# Altium schematic sheet USB_HUB.SchDoc(USB_HUB)
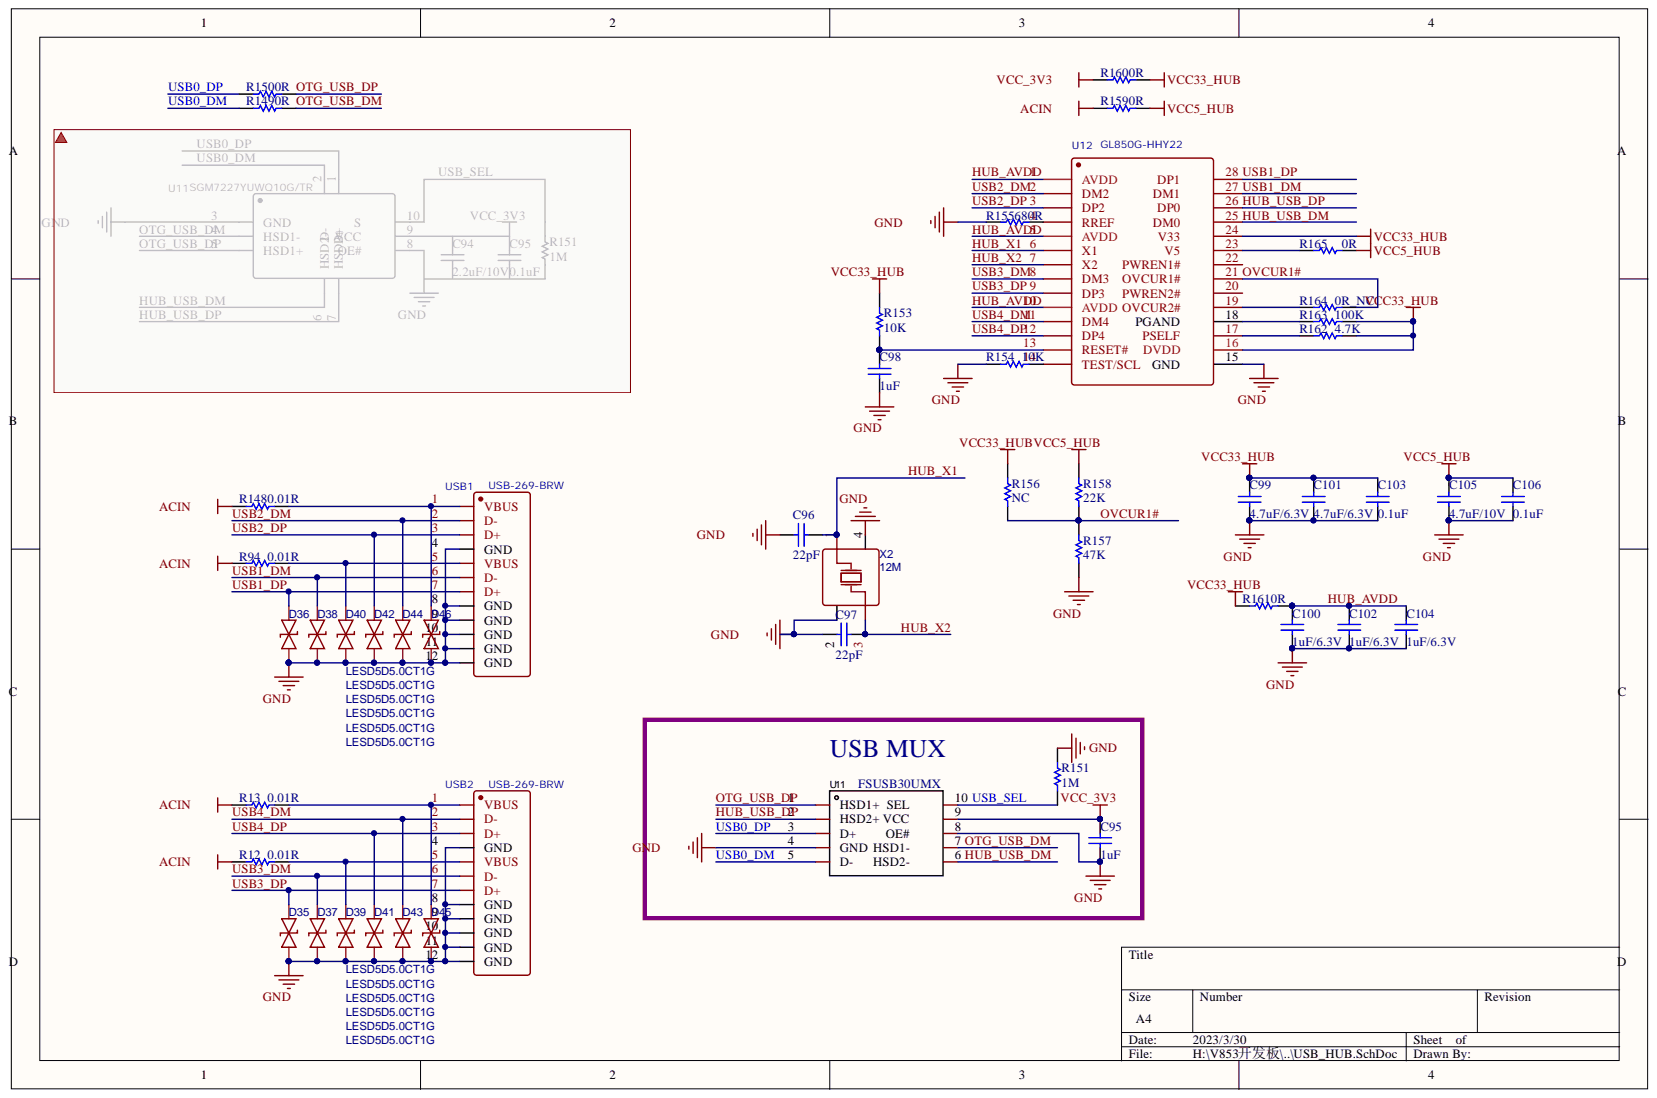
[diagram p0: USB_HUB.SchDoc]

## Parts
C95  1uF  [0402]
C96  22pF  [0402]
C97  22pF  [0402]
C98  1uF  [0402]
C99  4.7uF/6.3V  [0402]
C100  1uF/6.3V  [0402]
C101  4.7uF/6.3V  [0402]
C102  1uF/6.3V  [0402]
C103  0.1uF  [0402]
C104  1uF/6.3V  [0402]
C105  4.7uF/10V  [0402]
C106  0.1uF  [0402]
D35  LESD5D5.0CT1G  [SOD-523_L1.2-W0.8-LS1.6-BI]
D36  LESD5D5.0CT1G  [SOD-523_L1.2-W0.8-LS1.6-BI]
D37  LESD5D5.0CT1G  [SOD-523_L1.2-W0.8-LS1.6-BI]
D38  LESD5D5.0CT1G  [SOD-523_L1.2-W0.8-LS1.6-BI]
D39  LESD5D5.0CT1G  [SOD-523_L1.2-W0.8-LS1.6-BI]
D40  LESD5D5.0CT1G  [SOD-523_L1.2-W0.8-LS1.6-BI]
D41  LESD5D5.0CT1G  [SOD-523_L1.2-W0.8-LS1.6-BI]
D42  LESD5D5.0CT1G  [SOD-523_L1.2-W0.8-LS1.6-BI]
D43  LESD5D5.0CT1G  [SOD-523_L1.2-W0.8-LS1.6-BI]
D44  LESD5D5.0CT1G  [SOD-523_L1.2-W0.8-LS1.6-BI]
D45  LESD5D5.0CT1G  [SOD-523_L1.2-W0.8-LS1.6-BI]
D46  LESD5D5.0CT1G  [SOD-523_L1.2-W0.8-LS1.6-BI]
R12  0.01R  [0805R]
R13  0.01R  [0805R]
R94  0.01R  [0805R]
R148  0.01R  [0805R]
R149  0R  [0402]
R150  0R  [0402]
R151  1M  [0402]
R153  10K  [0402]
R154  10K  [0402]
R155  680R  [0402]
R156  NC  [0402]
R157  47K  [0402]
R158  22K  [0402]
R159  0R  [0402]
R160  0R  [0402]
R161  0R  [0402]
R162  4.7K  [0402]
R163  100K  [0402]
R164  0R_NC  [0402]
R165  0R  [0402]
U11  FSUSB30UMX  [UMLP-10_L1.8-W1.4-P0.40-BL]
U12  GL850G-HHY22  [SSOP-28_L10.2-W5.3-P0.65-LS7.8-BL]
USB1  USB-269-BRW  [USB-A-TH_USB-269-BRW]
USB2  USB-269-BRW  [USB-A-TH_USB-269-BRW]
X2  12M  [OSC-SMD_4P-L3.2-W2.5-BL]

## Nets
ACIN: R12.2 R13.2 R94.2 R148.2 R159.2
GND: C95.1 C96.1 C97.1 C98.2 C99.1 C100.1 C101.1 C102.1 C103.2 C104.1 C105.1 C106.2 D35.1 D36.1 D37.1 D38.1 D39.1 D40.1 D41.1 D42.1 D43.1 D44.1 D45.1 D46.1 R151.1 R154.2 R155.2 R157.1 U11.4(GND) U11.8 U12.15 USB1.4(GND) USB1.8(GND) USB1.9(GND) USB1.10(GND) USB1.11(GND) USB1.12(GND) USB2.4(GND) USB2.8(GND) USB2.9(GND) USB2.10(GND) USB2.11(GND) USB2.12(GND) X2.2 X2.4
VCC5_HUB: C105.2 C106.1 R158.2 R159.1 R165.1
VCC33_HUB: C99.2 C101.2 C103.1 R153.1 R156.2 R160.1 R161.2 R162.1 R163.1 U12.16 U12.24
VCC_3V3: C95.2 R160.2 U11.9
HUB_AVDD: C100.2 C102.2 C104.2 R161.1 U12.1(AVDD) U12.5(AVDD) U12.10
HUB_USB_DM: U11.6 U12.25
HUB_USB_DP: U11.2 U12.26
HUB_X1: C96.2 U12.6(X1) X2.1
HUB_X2: C97.2 U12.7(X2) X2.3
OTG_USB_DM: R149.1 U11.7
OTG_USB_DP: R150.1 U11.1(HSD1+)
OVCUR1#: R156.1 R157.2 R158.1 R164.1 U12.21
USB0_DM: R149.2 U11.5(D-)
USB0_DP: R150.2 U11.3(D+)
USB1_DM: D38.2 U12.27 USB1.6(D-)
USB1_DP: D36.2 U12.28 USB1.7(D+)
USB2_DM: D44.2 U12.2(DM2) USB1.2(D-)
USB2_DP: D42.2 U12.3(DP2) USB1.3(D+)
USB3_DM: D37.2 U12.8(DM3) USB2.6(D-)
USB3_DP: D35.2 U12.9(DP3) USB2.7(D+)
USB4_DM: D43.2 U12.11(DM4) USB2.2(D-)
USB4_DP: D41.2 U12.12(DP4) USB2.3(D+)
USB_SEL: R151.2 U11.10
NetC98_1: C98.1 R153.2 U12.13(RESET#)
NetD39_2: D39.2 R12.1 USB2.5(VBUS)
NetD40_2: D40.2 R94.1 USB1.5(VBUS)
NetD45_2: D45.2 R13.1 USB2.1(VBUS)
NetD46_2: D46.2 R148.1 USB1.1(VBUS)
NetR154_1: R154.1 U12.14(TEST/SCL)
NetR155_1: R155.1 U12.4(RREF)
NetR162_2: R162.2 U12.17
NetR163_2: R163.2 U12.18
NetR164_2: R164.2 U12.19
NetR165_2: R165.2 U12.23

Not connected: U12 20 22

## Library data of the parts
C95-C106 = Cap: Capacitor; PartNumber: CAP100NF; Component Kind: Standard; LatestRevisionDate: 17-Jul-2002; LatestRevisionNote: Re-released for DXP Platform.; Library Name: Miscellaneous Devices.IntLib; PackageReference: 0402; Published: 8-Jun-2000; Signal Integrity: Cap; Simulation: CAP; Publisher: Altium Limited
  C95 C98: Value: 1uF
  C96 C97: Value: 22pF
  C99 C101: Value: 4.7uF/6.3V
  C100 C102 C104: Value: 1uF/6.3V
  C103 C106: Value: 0.1uF
  C105: Value: 4.7uF/10V
D35-D46 = LESD5D5.0CT1G: BOM_Manufacturer: Leshan Radio; Contributor: LCSC; BOM_JLCPCB Part Class: Extended Part; BOM_Manufacturer Part: LESD5D5.0CT1G; BOM_Supplier: LCSC; BOM_Supplier Part: C383211; Component Kind: Standard; spicePre: D; spiceSymbolName: LESD5D5.0CT1G
R12 R13 R94 R148 R151 R153-R158 R162-R165 = Res1: Resistor; PartNumber: RES22R; Component Kind: Standard; LatestRevisionDate: 17-Jul-2002; LatestRevisionNote: Re-released for DXP Platform.; Library Name: Miscellaneous Devices.IntLib; PackageReference: 0402; Published: 8-Jun-2000; Signal Integrity: Res; Simulation: RESISTOR; Publisher: Altium Limited
  R12 R13 R94 R148: Value: 0.01R
  R151: Value: 1M
  R153 R154: Value: 10K
  R155: Value: 680R
  R156: Value: NC
  R157: Value: 47K
  R158: Value: 22K
  R162: Value: 4.7K
  R163: Value: 100K
  R164: Value: 0R_NC
  R165: Value: 0R
R149 R150 R159-R161 = Res1: Resistor; PartNumber: RES2.2K; Component Kind: Standard; LatestRevisionDate: 17-Jul-2002; LatestRevisionNote: Re-released for DXP Platform.; Library Name: Miscellaneous Devices.IntLib; PackageReference: 0402; Published: 8-Jun-2000; Signal Integrity: Res; Simulation: RESISTOR; Value: 0R; Publisher: Altium Limited
U11 = FSUSB30UMX: Add into BOM: yes; Component Kind: Standard; Convert to PCB: yes; Datasheet: https://atta.szlcsc.com/upload/public/pdf/source/20170116/1484561233176.pdf; Device: FSUSB30UMX; JLCPCB Part Class: Extended Part; Manufacturer Part: FSUSB30UMX; Name: FSUSB30UMX; PCB3D: UMLP-10_L1.8-W1.4-H0.5-P0.40; Supplier: LCSC; Supplier Footprint: UMLP-10; Supplier Part: C10885; Symbol: FSUSB30UMX
X2 = 25M: BOM_Manufacturer: TKD; BOM_Manufacturer Part: SX32Y025000BA1T; Contributor: LCSC; nameAlias: Frequency; BOM_JLCPCB Part Class: Extended Part; BOM_Supplier: LCSC; BOM_Supplier Part: C271631; Component Kind: Standard; Library Name: HM2.SchLib; spicePre: X; spiceSymbolName: SX32Y025000BA1T
U12 = GL850G-HHY22: Component Kind: Standard; JLC NO: C136617
USB1 USB2 = USB-269-BRW: Component Kind: Standard; JLC NO: C720548
